# Altium schematic sheet PAGE2 - STM NUCLEO OTII TC.SchDoc("PAGE2 - STM NUCLEO OTII TC")
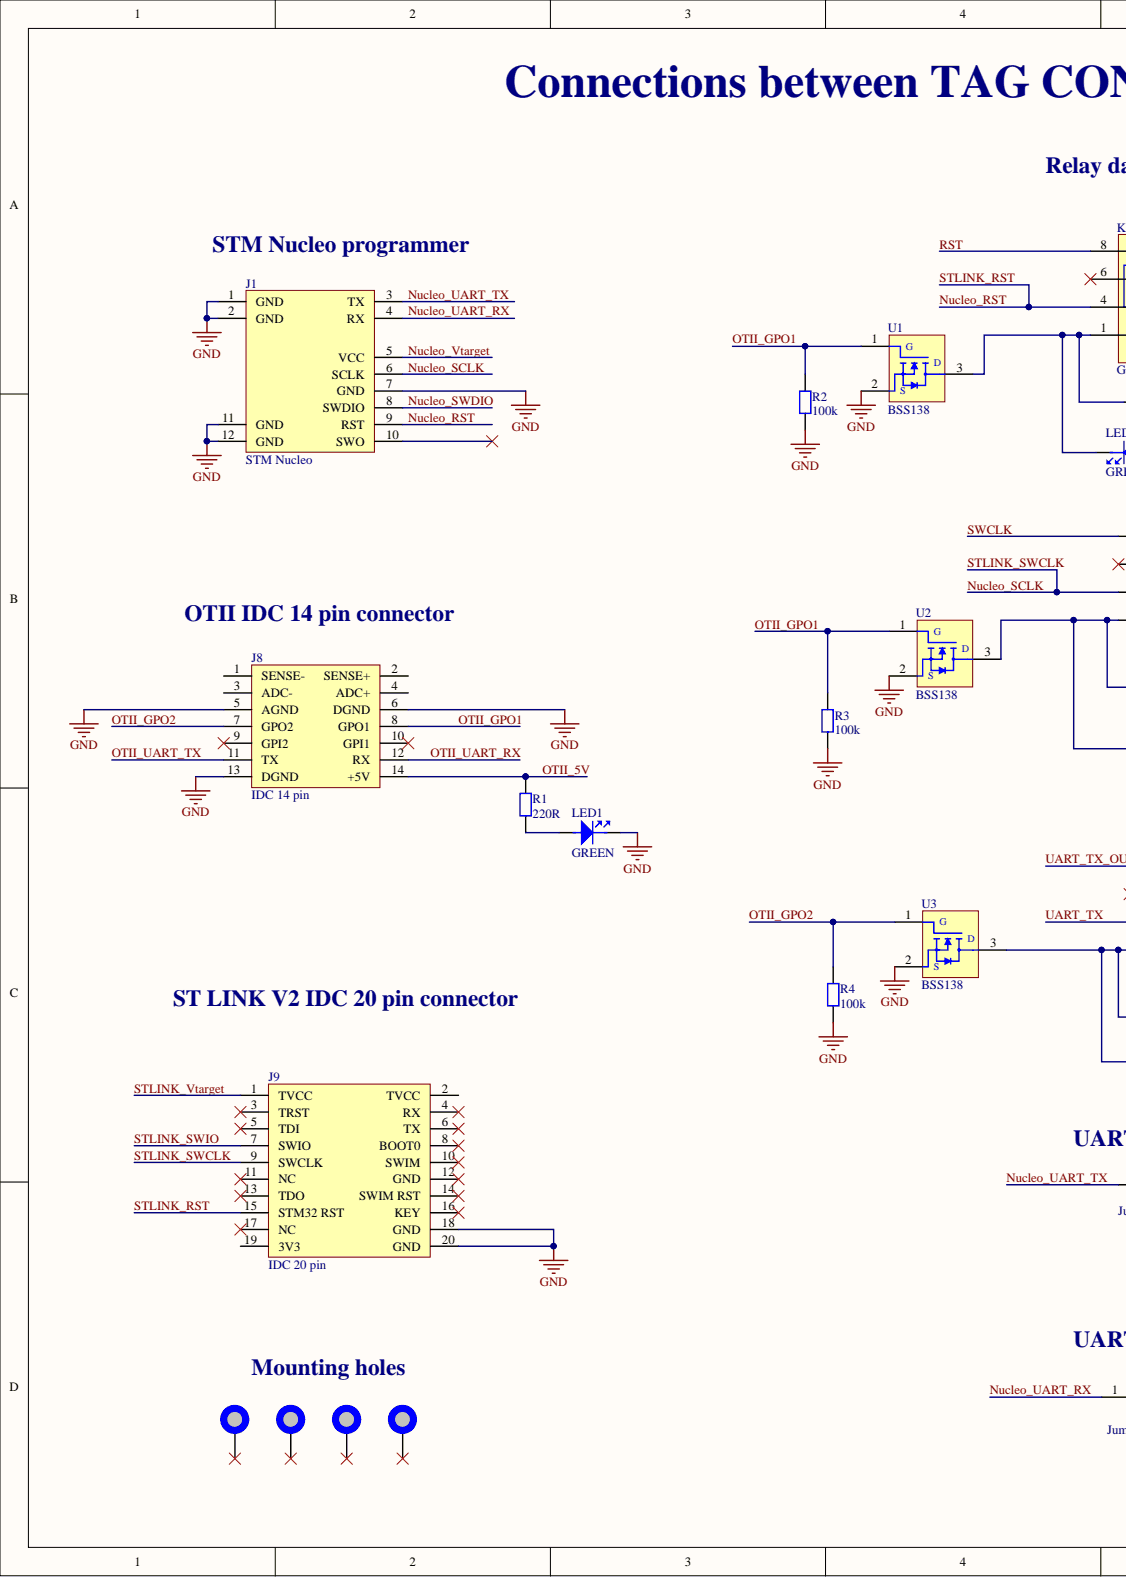
[diagram p0: PAGE2 - STM NUCLEO OTII TC.SchDoc, left]
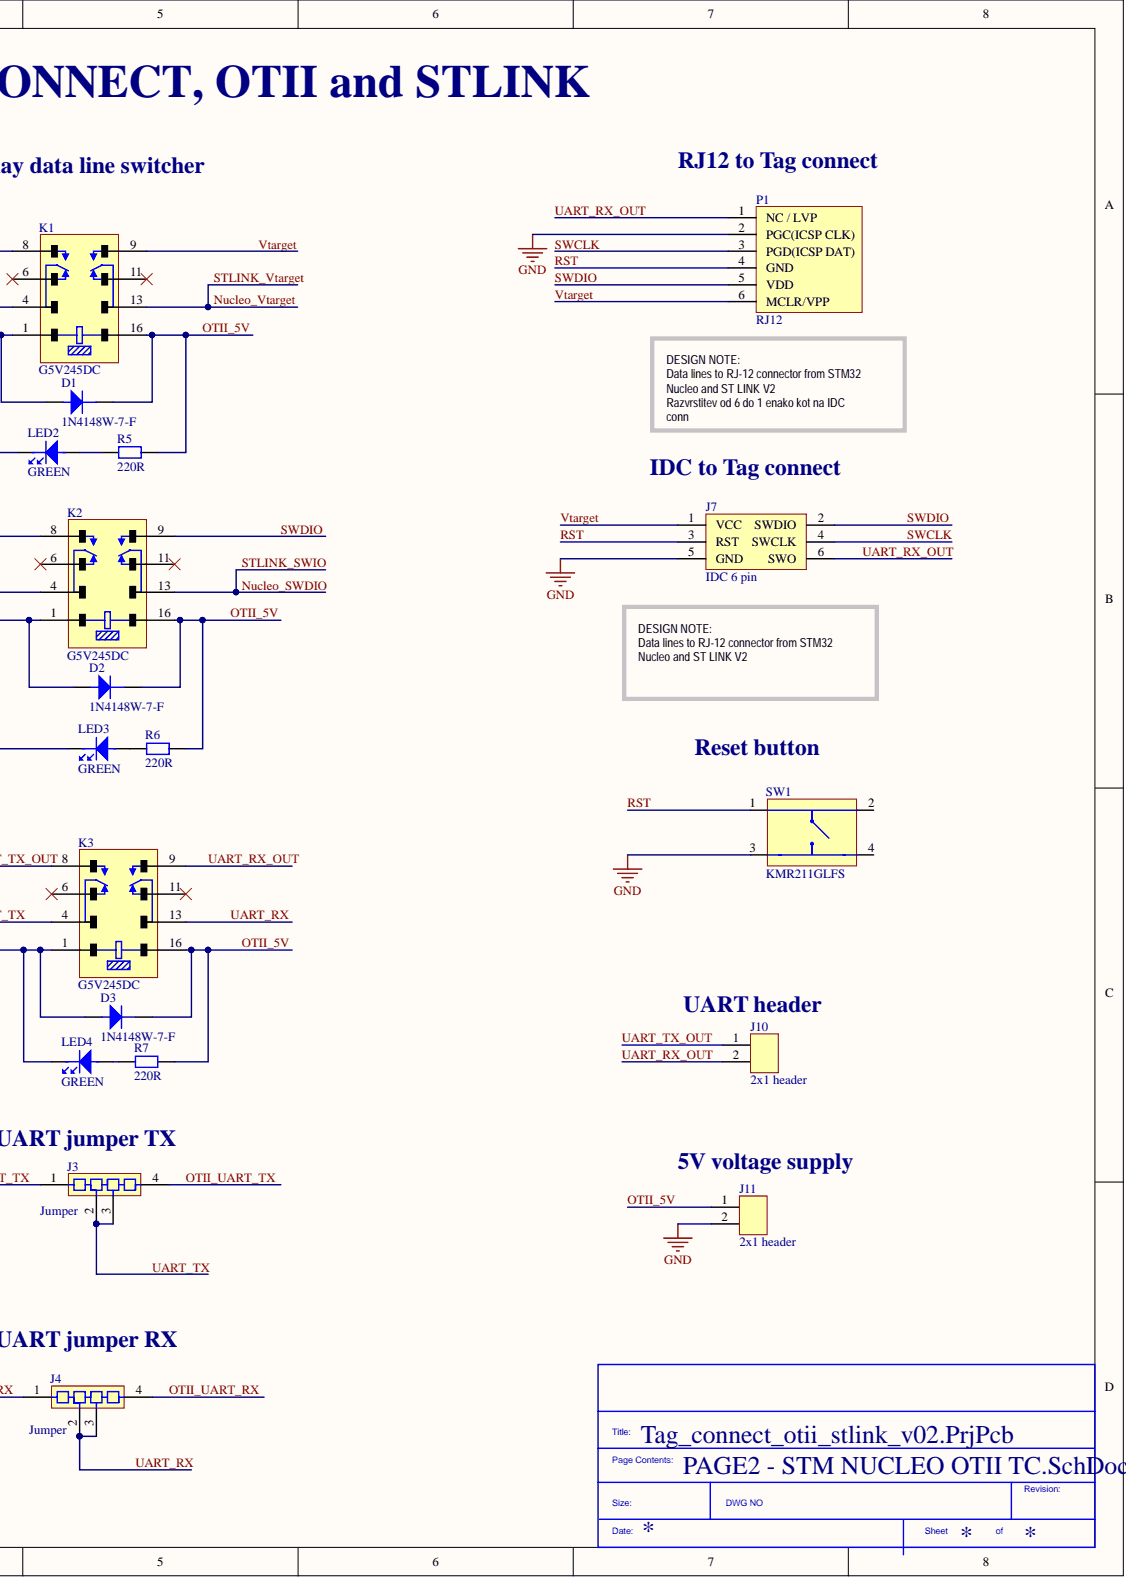
[diagram p1: PAGE2 - STM NUCLEO OTII TC.SchDoc, right]

## Parts
*  Mounting hole 3.3 mm  [MH_3.3]  (value not drawn)
*  Mounting hole 3.3 mm  [MH_3.3]  (value not drawn)
*  Mounting hole 3.3 mm  [MH_3.3]  (value not drawn)
*  Mounting hole 3.3 mm  [MH_3.3]  (value not drawn)
D1  1N4148W-7-F  [1N4148W-7-F]
D2  1N4148W-7-F  [1N4148W-7-F]
D3  1N4148W-7-F  [1N4148W-7-F]
J1  STM Nucleo  [NUCLEO F4 HEADERS]
J3  Jumper  [jumper4]
J4  Jumper  [jumper4]
J7  IDC 6 pin  [IDC 6 pin]
J8  IDC 14 pin  [IDC 14 PIN]
J9  IDC 20 pin  [IDC 20 pin]
J10  2x1 header  [2 pin]
J11  2x1 header  [2 pin]
K1  G5V245DC  [G5V245DC]
K2  G5V245DC  [G5V245DC]
K3  G5V245DC  [G5V245DC]
LED1  GREEN  [LED 0603]
LED2  GREEN  [LED 0603]
LED3  GREEN  [LED 0603]
LED4  GREEN  [LED 0603]
P1  RJ12  [RJ12]
R1  220R  [R0603]
R2  100k  [R0603]
R3  100k  [R0603]
R4  100k  [R0603]
R5  220R  [R0603]
R6  220R  [R0603]
R7  220R  [R0603]
SW1  KMR211GLFS  [Button]
U1  BSS138  [BSS138]
U2  BSS138  [BSS138]
U3  BSS138  [BSS138]

## Nets
GND: J1.1(GND) J1.2(GND) J1.7(GND) J1.11(GND) J1.12(GND) J7.5(GND) J8.5(AGND) J8.6(DGND) J8.13(DGND) J9.18(GND) J9.20(GND) J11.2 LED1.1 P1.2(PGC(ICSP_CLK)) R2.1 R3.1 R4.1 SW1.3 U1.2 U2.2 U3.2
Nucleo_RST: J1.9(RST) J9.15(STM32_RST) K1.4
Nucleo_SCLK: J1.6(SCLK) J9.9(SWCLK) K2.4
Nucleo_SWDIO: J1.8(SWDIO) J9.7(SWIO) K2.13
Nucleo_UART_RX: J1.4(RX) J4.1
Nucleo_UART_TX: J1.3(TX) J3.1
Nucleo_Vtarget: J1.5(VCC) J9.1(TVCC) K1.13
OTII_5V: D1.1 D2.1 D3.1 J8.14(+5V) J11.1 K1.16 K2.16 K3.16 R1.2 R5.1 R6.1 R7.2
OTII_GPO1: J8.8(GPO1) R2.2 R3.2 U1.1 U2.1
OTII_GPO2: J8.7(GPO2) R4.2 U3.1
OTII_UART_RX: J4.4 J8.12(RX)
OTII_UART_TX: J3.4 J8.11(TX)
RST: J7.3(RST) K1.8 P1.4(GND) SW1.1
SWCLK: J7.4(SWCLK) K2.8 P1.3(PGD(ICSP_DAT))
SWDIO: J7.2(SWDIO) K2.9 P1.5(VDD)
UART_RX: J4.2 J4.3 K3.13
UART_RX_OUT: J7.6(SWO) J10.2 K3.9 P1.1(NC_/_LVP)
UART_TX: J3.2 J3.3 K3.4
UART_TX_OUT: J10.1 K3.8
Vtarget: J7.1(VCC) K1.9 P1.6(MCLR/VPP)
NetD1_2: D1.2 K1.1 LED2.1 U1.3
NetD2_2: D2.2 K2.1 LED3.1 U2.3
NetD3_2: D3.2 K3.1 LED4.1 U3.3
NetLED1_2: LED1.2 R1.1
NetLED2_2: LED2.2 R5.2
NetLED3_2: LED3.2 R6.2
NetLED4_2: LED4.2 R7.1

Not connected: * 1; J1 10(SWO); J8 1(SENSE-) 2(SENSE+) 3(ADC-) 4(ADC+) 9(GPI2) 10(GPI1); J9 2(TVCC) 3(TRST) 4(RX) 5(TDI) 6(TX) 8(BOOT0) 10(SWIM) 11(NC) 12(GND) 13(TDO) 14(SWIM_RST) 16(KEY) 17(NC) 19(3V3); K1 6 11; K2 6 11; K3 6 11; SW1 2 4

## Library data of the parts
* * * * = MH_3_3: Description: Mounting hole 3.3 mm; Component Kind: Standard; Library Name: TC_otii_stlink.SchLib
D1-D3 = 1N4148W-7-F: DIODE GEN PURP 100V 300MA SOD123; Manufacturer: Diodes; Voltage Rating (DC): 75V; Reverse Recovery Time: 4ns; Power Rating: 400mW; Polarity: Standard; Peak Reverse Current: 1uA; Peak Non-Repetitive Surge Current: 2A; Output Current: 150mA; Forward Voltage: 1.25V; Element Configuration: Single; Capacitance: 2pF; Width: 1.7mm; Weight: 0.000353oz; Voltage: 100V; Supplier Part Number 1: 1776392; Supplier 1: Farnell; Stock 1: 994731; Recovery Time: 4ns; REACH SVHC: No SVHC; Radiation Hardening: No; Pricing 1: 5=0.155, 25=0.151, 100=0.0525, 250=0.0496, 500=0.0474, 1000=0.0247, 5000=0.0213 (EUR); Power Dissipation: 400mW; Packaging: Tape and Reel; Package Quantity: 3000; Number of Pins: 2; Mount: Surface Mount; Min Operating Temperature: -65°C; Max Surge Current: 2A; Max Reverse Voltage (DC): 100V; Max Repetitive Reverse Voltage (Vrrm): 100V; Max Operating Temperature: 150°C; Max Forward Surge Current (Ifsm): 2A; Manufacturer Part Number: 1N4148W-7-F; Length: 2.85mm; Lead Free: Lead Free; Height: 1.25mm; Forward Current: 150mA; Current Rating: 150mA; Contact Plating: Tin,Matte; ComponentLink6URL: https://www.diodes.com/assets/Datasheets/ds30086.pdf?src-supplier=Verical; ComponentLink6Description: https://www.diodes.com/assets/Datasheets/ds30086.pdf?src-supplier=Verical; ComponentLink5URL: https://www.diodes.com/assets/Datasheets/ds30086.pdf?src-supplier=Avnet+Europe; ComponentLink5Description: https://www.diodes.com/assets/Datasheets/ds30086.pdf?src-supplier=Avnet+Europe; ComponentLink4URL: http://www.mouser.com/ds/2/115/ds30086-60872.pdf?src-supplier=Mouser; ComponentLink4Description: http://www.mouser.com/ds/2/115/ds30086-60872.pdf?src-supplier=Mouser; ComponentLink3URL: https://www.diodes.com/assets/Datasheets/ds30086.pdf?src-supplier=Avnet; ComponentLink3Description: https://www.diodes.com/assets/Datasheets/ds30086.pdf?src-supplier=Avnet; ComponentLink2URL: http://www.farnell.com/datasheets/1915529.pdf?src-supplier=Element14; ComponentLink2Description: http://www.farnell.com/datasheets/1915529.pdf?src-supplier=Element14; ComponentLink1URL: https://www.diodes.com/assets/Datasheets/ds30086.pdf?src-supplier=Digi-Key; ComponentLink1Description: https://www.diodes.com/assets/Datasheets/ds30086.pdf?src-supplier=Digi-Key; Component Kind: Standard; Average Rectified Current: 300mA; Library Name: TC_otii_stlink.SchLib
J3 J4 = Jumper_1: 4 pin jumper; Component Kind: Standard; Library Name: TC_otii_stlink.SchLib
J7 = 90130-1206: Headers & Wire Housings 2.54MM CGRIDIII HDR 6P V DR SHRD AU-E; Manufacturer: Molex; Working Voltage: 350V; Number of Positions: 6; Max Voltage Rating (DC): 350.35kV; Max Voltage Rating (AC): 500V; Max Current Rating: 3.9A; Insulation Resistance: 5GR; Glow Wire Compliant: Non-compliant; Gender: Male; Current Rating: 3A; Contact Resistance: 20mR; Voltage Rating (DC): 350V; Voltage Rating (AC): 350V; Voltage Rating: 350V; Termination: Solder; Supplier Part Number 1: 9733884; Supplier 1: Farnell; Stock 1: 1896; Row Spacing: 2.54mm; REACH SVHC: Unknown; Radiation Hardening: No; Pricing 1: 1=1.07, 50=1, 100=0.934, 250=0.84, 500=0.775, 1000=0.619 (EUR); Pitch: 2.54mm; Packaging: Tray; Package Quantity: 2560; Orientation: Vertical; Number of Rows: 2; Number of Poles: 6; Number of Contacts: 6; Number of Circuits: 6; Mount: Through Hole; Min Operating Temperature: -55°C; Max Operating Temperature: 125°C; Material: Glass,Polyester; Manufacturer Part Number: 90130-1206; Length: 8mm; Lead Pitch: 2.54mm; Lead Free: Lead Free; Housing Material: Polyester; Housing Color: Black; Height: 4.7mm; Depth: 3.94mm; Contact Plating: Gold; Contact Material: Brass,Bronze,Copper; Contact Gender: Male; ComponentLink5URL: http://uk.rs-online.com/webdocs/13c4/0900766b813c4c7b.pdf?src-supplier=RSComponents; ComponentLink5Description: http://uk.rs-online.com/webdocs/13c4/0900766b813c4c7b.pdf?src-supplier=RSComponents; ComponentLink4URL: http://www.molex.com/webdocs/datasheets/pdf/en-us/0901301206_PCB_HEADERS.pdf?src-supplier=Avnet; ComponentLink4Description: http://www.molex.com/webdocs/datasheets/pdf/en-us/0901301206_PCB_HEADERS.pdf?src-supplier=Avnet; ComponentLink3URL: http://www.molex.com/webdocs/datasheets/pdf/en-us/0901301206_PCB_HEADERS.pdf?src-supplier=Avnet+Europe; ComponentLink3Description: http://www.molex.com/webdocs/datasheets/pdf/en-us/0901301206_PCB_HEADERS.pdf?src-supplier=Avnet+Europe; ComponentLink2URL: http://www.jameco.com/Jameco/Products/ProdDS/1978810.pdf?src-supplier=Jameco; ComponentLink2Description: http://www.jameco.com/Jameco/Products/ProdDS/1978810.pdf?src-supplier=Jameco; ComponentLink1URL: http://www.mouser.com/ds/2/276/0901301206_PCB_HEADERS-151694.pdf?src-supplier=Mouser; ComponentLink1Description: http://www.mouser.com/ds/2/276/0901301206_PCB_HEADERS-151694.pdf?src-supplier=Mouser; Component Kind: Standard; Color: Black; Library Name: TC_otii_stlink.SchLib
J8 = 70246-1404: Conn Shrouded Header (4 Sides) HDR 14 POS 2.54mm Solder ST Thru-Hole C-Grid® Frame; Manufacturer: Molex; Voltage Rating (DC): 250V; Number of Positions: 14; Glow Wire Compliant: Non-compliant; Gender: Male; Current Rating: 2.5A; Contact Post Length: 3.43mm; Voltage Rating: 250V; Termination: Solder; Supplier Part Number 1: 1392520; Supplier 1: Farnell; Stock 1: 3001; Row Spacing: 2.54mm; REACH SVHC: Unknown; Radiation Hardening: No; Pricing 1: 1=1.55, 50=1.48, 100=1.42, 250=1.29, 500=1.17, 1000=0.966 (EUR); Pitch: 2.54mm; Packaging: Tray; Orientation: Vertical; Number of Rows: 2; Number of Contacts: 14; Number of Circuits: 14; Mount: Through Hole; Min Operating Temperature: -55°C; Max Voltage Rating (DC): 250V; Max Operating Temperature: 120°C; Manufacturer Part Number: 70246-1404; Housing Material: Polyester; Flammability Rating: UL94 V-0; ELV: Compliant; Contact Plating: Gold,Tin; Contact Mating Length: 6.1mm; Contact Material: Bronze,Gold; Contact Gender: Male; ComponentLink5URL: http://www.molex.com/webdocs/datasheets/pdf/en-us/0702461404_PCB_HEADERS.pdf?src-supplier=Avnet+Europe; ComponentLink5Description: http://www.molex.com/webdocs/datasheets/pdf/en-us/0702461404_PCB_HEADERS.pdf?src-supplier=Avnet+Europe; ComponentLink4URL: http://www.mouser.com/ds/2/276/0702461404_PCB_HEADERS-172392.pdf?src-supplier=Mouser; ComponentLink4Description: http://www.mouser.com/ds/2/276/0702461404_PCB_HEADERS-172392.pdf?src-supplier=Mouser; ComponentLink3URL: http://uk.rs-online.com/webdocs/13c4/0900766b813c492f.pdf?src-supplier=RSComponents; ComponentLink3Description: http://uk.rs-online.com/webdocs/13c4/0900766b813c492f.pdf?src-supplier=RSComponents; ComponentLink2URL: http://www.molex.com/webdocs/datasheets/pdf/en-us/0702461404_PCB_HEADERS.pdf?src-supplier=Avnet; ComponentLink2Description: http://www.molex.com/webdocs/datasheets/pdf/en-us/0702461404_PCB_HEADERS.pdf?src-supplier=Avnet; ComponentLink1URL: http://www.farnell.com/datasheets/2051710.pdf?src-supplier=Element14; ComponentLink1Description: http://www.farnell.com/datasheets/2051710.pdf?src-supplier=Element14; Component Kind: Standard; Library Name: TC_otii_stlink.SchLib
J9 = 70246-2004: Headers & Wire Housings 2.54mm CGrid Hdr Shr d /Slt .13AuLF 20Ckt; Manufacturer: Molex; Voltage Rating (DC): 250V; Number of Positions: 20; Glow Wire Compliant: Non-compliant; Gender: Male; Current Rating: 2.5A; Voltage Rating: 250V; Termination: Solder; Supplier Part Number 1: 1392410; Supplier 1: Farnell; Stock 1: 2985; REACH SVHC: Unknown; Radiation Hardening: No; Pricing 1: 1=1.62, 50=1.52, 100=1.41, 250=1.28, 500=1.17, 1000=0.943 (EUR); Pitch: 2.54mm; Packaging: Tray; Package Quantity: 720; Orientation: Vertical; Number of Rows: 2; Number of Contacts: 20; Number of Circuits: 20; Mount: Through Hole; Min Operating Temperature: -55°C; Max Voltage Rating (DC): 250V; Max Operating Temperature: 120°C; Manufacturer Part Number: 70246-2004; Flammability Rating: UL94 V-0; ELV: Compliant; Contact Plating: Gold; Contact Material: Gold,Bronze; ComponentLink5URL: http://uk.rs-online.com/webdocs/13c4/0900766b813c4938.pdf?src-supplier=RSComponents; ComponentLink5Description: http://uk.rs-online.com/webdocs/13c4/0900766b813c4938.pdf?src-supplier=RSComponents; ComponentLink4URL: http://www.molex.com/webdocs/datasheets/pdf/en-us/0702462004_PCB_HEADERS.pdf?src-supplier=Avnet; ComponentLink4Description: http://www.molex.com/webdocs/datasheets/pdf/en-us/0702462004_PCB_HEADERS.pdf?src-supplier=Avnet; ComponentLink3URL: http://www.molex.com/webdocs/datasheets/pdf/en-us/0702462004_PCB_HEADERS.pdf?src-supplier=Avnet+Europe; ComponentLink3Description: http://www.molex.com/webdocs/datasheets/pdf/en-us/0702462004_PCB_HEADERS.pdf?src-supplier=Avnet+Europe; ComponentLink2URL: http://www.mouser.com/ds/2/276/0702462004_PCB_HEADERS-150189.pdf?src-supplier=Mouser; ComponentLink2Description: http://www.mouser.com/ds/2/276/0702462004_PCB_HEADERS-150189.pdf?src-supplier=Mouser; ComponentLink1URL: http://www.farnell.com/datasheets/2051704.pdf?src-supplier=Element14; ComponentLink1Description: http://www.farnell.com/datasheets/2051704.pdf?src-supplier=Element14; Component Kind: Standard; Library Name: TC_otii_stlink.SchLib
J10 J11 = 2 pin header: Component Kind: Standard; Library Name: TC_otii_stlink.SchLib
K1-K3 = G5V245DC: OMRON ELECTRONIC COMPONENTS         G5V-2 4.5DC            Signal Relay, G5V-2 Series, DPDT, 4.5 VDC, 2 A, Through Hole, Non Latching; Switching Current: 2A; Coil Voltage (DC): 4.5V; Coil Type: Non-Latching; Coil Resistance: 40R; Coil Power: 500mW; Termination: Through Hole; Supplier Part Number 1: 9949810; Supplier 1: Farnell; Stock 1: 337; REACH SVHC: Unknown; Pricing 1: 1=1.89, 25=1.76, 50=1.24, 250=1.14, 500=1.12 (EUR); Mount: PCB; Min Operating Temperature: -25°C; Max Operating Temperature: 65°C; Manufacturer Part Number: G5V245DC; Manufacturer: Omron; Length: 20.5mm; Height: 11.5mm; Depth: 10.1mm; Contact Material: Silver,Gold; Contact Current Rating: 2A; ComponentLink2URL: https://www.distrelec.biz/Web/Downloads/_t/ds/g5v2_eng_tds.pdf?mime=application%2Fpdf&src-supplier=Distrelec; ComponentLink2Description: https://www.distrelec.biz/Web/Downloads/_t/ds/g5v2_eng_tds.pdf?mime=application%2Fpdf&src-supplier=Distrelec; ComponentLink1URL: http://www.farnell.com/datasheets/724502.pdf?src-supplier=Element14; ComponentLink1Description: http://www.farnell.com/datasheets/724502.pdf?src-supplier=Element14; Component Kind: Standard; Library Name: TC_otii_stlink.SchLib
LED1-LED4 = KP-1608CGCK: KINGBRIGHT - KP-1608CGCK - LED, 0603, 50MCD, GREEN; Wavelength: 570nm; Viewing Angle: 120°; Number of LEDs: 1; Lens Style: Rectangular,Diffused,Colorless; Lens Color: Clear; Forward Voltage: 2.1V; Forward Current: 20mA; Width: 1.6mm; Supplier Part Number 1: 2290328; Supplier 1: Farnell; Stock 1: 18535; REACH SVHC: No SVHC; Radiation Hardening: No; Pricing 1: 5=0.157, 50=0.143, 100=0.0934, 500=0.0789, 1000=0.0621 (EUR); Packaging: Tape and Reel; Number of Pins: 2; Mount: Surface Mount; Manufacturer Part Number: KP-1608CGCK; Manufacturer: Kingbright; Luminous Intensity: 50mcd; Length: 0.8mm; Height: 1.1mm; Dominant Wavelength: 570nm; ComponentLink2URL: http://www.rapidonline.com/pdf/72-8670.pdf?src-supplier=Rapid+Electronics; ComponentLink2Description: http://www.rapidonline.com/pdf/72-8670.pdf?src-supplier=Rapid+Electronics; ComponentLink1URL: http://www.farnell.com/datasheets/2045956.pdf?src-supplier=Element14; ComponentLink1Description: http://www.farnell.com/datasheets/2045956.pdf?src-supplier=Element14; Component Kind: Standard; Color: Green; Case/Package: 1608; Library Name: TC_otii_stlink.SchLib
P1 = 95501-2661: MODULAR, JACK, THT, R/A, RJ11, 6P6C; Manufacturer: Molex; Voltage Rating (DC): 125V; Shielding: Unshielded; Pitch: 2.54mm; Number of Positions: 6; Number of Ports: 1; Insulation Resistance: 500MR; Gender: Female; Current Rating: 1.5A; Approvals: UL; Width: 11.5mm; Termination: Through Hole; Supplier Part Number 1: 1536490; Supplier 1: Farnell; Stock 1: 7441; REACH SVHC: Unknown; Pricing 1: 10=0.565, 100=0.492, 500=0.443, 1000=0.394, 2500=0.356, 5000=0.344 (EUR); Packaging: Tray; Package Quantity: 3276; Orientation: Right Angle; Number of Contacts: 6; Mount: Through Hole; Min Operating Temperature: -40°C; Max Voltage Rating (DC): 125V; Max Operating Temperature: 80°C; Manufacturer Part Number: 95501-2661; Length: 13.21mm; Lead Free: Lead Free; Insulation Material: Polyester; Housing Material: Thermoplastic; Halogen Free: Low Halogen; Flammability Rating: UL94 V-0; Depth: 18.1mm; Contact Plating: Gold; Contact Material: Copper Alloy,Bronze; ComponentLink4URL: http://www.onlinecomponents.com/productFiles/mf-MOL/360890955012661_modular_jacks_plug.pdf?src-supplier=Onlinecomponents.com; ComponentLink4Description: http://www.onlinecomponents.com/productFiles/mf-MOL/360890955012661_modular_jacks_plug.pdf?src-supplier=Onlinecomponents.com; ComponentLink3URL: http://www.mouser.com/ds/2/276/0955012661_MODULAR_JACKS_PLUG-177638.pdf?src-supplier=Mouser; ComponentLink3Description: http://www.mouser.com/ds/2/276/0955012661_MODULAR_JACKS_PLUG-177638.pdf?src-supplier=Mouser; ComponentLink2URL: http://www.farnell.com/cad/1597654.pdf?src-supplier=Element14; ComponentLink2Description: http://www.farnell.com/cad/1597654.pdf?src-supplier=Element14; ComponentLink1URL: http://uk.rs-online.com/webdocs/13c4/0900766b813c4eac.pdf?src-supplier=RSComponents; ComponentLink1Description: http://uk.rs-online.com/webdocs/13c4/0900766b813c4eac.pdf?src-supplier=RSComponents; Component Kind: Standard; Color: Black; Library Name: TC_otii_stlink.SchLib
R1 R5-R7 = CRCW0603220RFKEA: RES SMD 220 OHM 1% 1/10W 0603; Manufacturer: Vishay; Voltage Rating (DC): 75V; Temperature Coefficient: 100ppm/°C; Power Rating: 100mW; Width: 0.033inch; Weight: 7.1E-05oz; Voltage Rating: 75V; Tolerance: 1%; Termination: SMD/SMT; Supplier Part Number 1: 541-220HTR-ND; Supplier 1: Digi-Key; Stock 1: 0; Resistance: 220R; REACH SVHC: Unknown; Radiation Hardening: No; Packaging: Tape and Reel; Package Quantity: 10; Number of Terminations: 2; Min Operating Temperature: -55°C; Military Standard: Not; Max Power Dissipation: 100mW; Max Operating Temperature: 155°C; Manufacturer Part Number: CRCW0603220RFKEA; Length: 1.55mm; Lead Free: Lead Free; Height: 0.45mm; Features: Automotive AEC-Q200; Depth: 0.85mm; Contact Plating: Tin; Composition: Thick Film; ComponentLink11URL: http://www.farnell.com/datasheets/2111203.pdf?src-supplier=Element14; ComponentLink11Description: http://www.farnell.com/datasheets/2111203.pdf?src-supplier=Element14; ComponentLink10URL: https://www.distrelec.biz/Web/Downloads/a_/en/flD-CRCW-e3_data_en.pdf?mime=application%2Fpdf&src-supplier=Distrelec; ComponentLink10Description: https://www.distrelec.biz/Web/Downloads/a_/en/flD-CRCW-e3_data_en.pdf?mime=application%2Fpdf&src-supplier=Distrelec; ComponentLink9URL: http://www.vishay.com/docs/20035/dcrcwe3.pdf?src-supplier=Avnet+Europe; ComponentLink9Description: http://www.vishay.com/docs/20035/dcrcwe3.pdf?src-supplier=Avnet+Europe; ComponentLink8URL: https://www.verical.com/server-webapp/html/getDatasheet?partID=45816&src-supplier=Verical; ComponentLink8Description: https://www.verical.com/server-webapp/html/getDatasheet?partID=45816&src-supplier=Verical; ComponentLink7URL: https://www.alliedelec.com/m/d/0c934d6939ccefa68dbe0b96d821c8cf.pdf?src-supplier=Allied+Electronics; ComponentLink7Description: https://www.alliedelec.com/m/d/0c934d6939ccefa68dbe0b96d821c8cf.pdf?src-supplier=Allied+Electronics; ComponentLink6URL: http://uk.rs-online.com/webdocs/0d98/0900766b80d98afc.pdf?src-supplier=RSComponents; ComponentLink6Description: http://uk.rs-online.com/webdocs/0d98/0900766b80d98afc.pdf?src-supplier=RSComponents; ComponentLink5URL: http://www.vishay.com/docs/20035/dcrcwe3.pdf?src-supplier=Future+Electronics; ComponentLink5Description: http://www.vishay.com/docs/20035/dcrcwe3.pdf?src-supplier=Future+Electronics; ComponentLink4URL: http://www.vishay.com/docs/20035/dcrcwe3.pdf?src-supplier=Avnet; ComponentLink4Description: http://www.vishay.com/docs/20035/dcrcwe3.pdf?src-supplier=Avnet; ComponentLink3URL: http://www.farnell.com/datasheets/2045673.pdf?src-supplier=Element14; ComponentLink3Description: http://www.farnell.com/datasheets/2045673.pdf?src-supplier=Element14; ComponentLink2URL: http://www.mouser.com/ds/2/427/dcrcwe3-109170.pdf?src-supplier=Mouser; ComponentLink2Description: http://www.mouser.com/ds/2/427/dcrcwe3-109170.pdf?src-supplier=Mouser; ComponentLink1URL: http://www.vishay.com/docs/20035/dcrcwe3.pdf?src-supplier=Digi-Key; ComponentLink1Description: http://www.vishay.com/docs/20035/dcrcwe3.pdf?src-supplier=Digi-Key; Component Kind: Standard; Case Code (Metric): 1608; Case Code (Imperial): 0603; Case/Package: 0603; Library Name: TC_otii_stlink.SchLib
R2-R4 = CPF-A-0603B100KE1: TE CONNECTIVITY         CPF-A-0603B100KE1             SMD Chip Resistor, Thin Film, 100 kohm, 50 V, 0603 [1608 Metric], 62.5 mW,  0.1%, CPF-A Series; Voltage Rating (DC): 50V; Temperature Coefficient: 25ppm/°C; Resistance: 100kR; Power Rating: 63mW; Number of Resistors: 1; Voltage Rating: 50V; Tolerance: 0.1%; Termination: Solder; Supplier Part Number 1: 2483881; Supplier 1: Farnell; Stock 1: 5473; REACH SVHC: No SVHC; Pricing 1: 1=0.378, 50=0.279, 100=0.21, 250=0.199, 500=0.173, 1000=0.137, 5000=0.111 (EUR); Packaging: Tape and Reel; Number of Terminations: 2; Mount: Surface Mount; Min Operating Temperature: -55°C; Max Power Dissipation: 63mW; Max Operating Temperature: 155°C; Manufacturer Part Number: CPF-A-0603B100KE1; Manufacturer: TE Connectivity; Features: Moisture Resistant,Automotive AEC-Q200,Anti-Sulfur; ELV: Compliant; Composition: Thin Film; Component Kind: Standard; Case Code (Metric): 1608; Case Code (Imperial): 0603; Case/Package: 0603; Library Name: TC_otii_stlink.SchLib
SW1 = KMR211GLFS: SWITCH TACTILE SPST-NO 0.05A 32V; Manufacturer: ITT C&K; Throw Configuration: SPST; Switch Travel: 0.2mm; Switch Function: Off-Mom; Power Rating: 1W; Operating Force: 1.2N; Mechanical Life: 200000Cycles; Max Current Rating: 50mA; Illumination Type: Non-Illuminated,Illuminated; Actuator Type: Standard; Actuator Length: 0.5mm; Width: 2.8mm; Voltage Rating (DC): 32V; Termination: Gull Wing; Supplier Part Number 1: 1437635RL; Supplier 1: Farnell; Stock 1: 0; REACH SVHC: No SVHC; Radiation Hardening: No; Packaging: Tape and Reel; Package Quantity: 1; Orientation: Straight; Mount: Surface Mount; Min Operating Temperature: -40°C; Max Voltage Rating (DC): 32V; Max Operating Temperature: 85°C; Manufacturer Part Number: KMR211GLFS; Length: 2.8mm; Lead Free: Lead Free; Depth: 4.6mm; Current Rating: 50mA; Contact Plating: Silver; Contact Material: Silver; Contact Current Rating: 50mA; ComponentLink7URL: http://www.ckswitches.com/media/1479/kmr2.pdf?src-supplier=Onlinecomponents.com; ComponentLink7Description: http://www.ckswitches.com/media/1479/kmr2.pdf?src-supplier=Onlinecomponents.com; ComponentLink6URL: https://www.ckswitches.com/media/1479/kmr2.pdf?src-supplier=Digi-Key; ComponentLink6Description: https://www.ckswitches.com/media/1479/kmr2.pdf?src-supplier=Digi-Key; ComponentLink5URL: http://www.ck-components.com/14414/kmr2_9aug12.pdf/?src-supplier=Avnet+Europe; ComponentLink5Description: http://www.ck-components.com/14414/kmr2_9aug12.pdf/?src-supplier=Avnet+Europe; ComponentLink4URL: http://www.ck-components.com/14414/kmr2_9aug12.pdf/?src-supplier=Avnet; ComponentLink4Description: http://www.ck-components.com/14414/kmr2_9aug12.pdf/?src-supplier=Avnet; ComponentLink3URL: http://www.farnell.com/datasheets/2044619.pdf?src-supplier=Element14; ComponentLink3Description: http://www.farnell.com/datasheets/2044619.pdf?src-supplier=Element14; ComponentLink2URL: http://www1.futureelectronics.com/doc/C%20-%20K%20COMPONENTS/KMR211GLFS.pdf?src-supplier=Future+Electronics; ComponentLink2Description: http://www1.futureelectronics.com/doc/C%20-%20K%20COMPONENTS/KMR211GLFS.pdf?src-supplier=Future+Electronics; ComponentLink1URL: http://uk.rs-online.com/webdocs/129c/0900766b8129c9f0.pdf?src-supplier=RSComponents; ComponentLink1Description: http://uk.rs-online.com/webdocs/129c/0900766b8129c9f0.pdf?src-supplier=RSComponents; Component Kind: Standard; Library Name: TC_otii_stlink.SchLib
U1-U3 = BSS138: MOSFET N-CH 50V 220MA SOT-23; Manufacturer: ON Semiconductor / Fairchild; Turn-On Delay Time: 2.5ns; Turn-Off Delay Time: 20ns; Threshold Voltage: 1.3V; Rise Time: 9ns; Rds On Max: 3.5R; Power Dissipation: 360mW; On-State Resistance: 6R; Number of Elements: 1; Input Capacitance: 27pF; Gate to Source Voltage (Vgs): 20V; Element Configuration: Single; Width: 1.3mm; Weight: 0.03g; Voltage Rating (DC): 50V; Termination: SMD/SMT; Supplier Part Number 1: 9845330; Supplier 1: Farnell; Stock 1: 727806; REACH SVHC: No SVHC; Radiation Hardening: No; Pricing 1: 5=0.286, 50=0.261, 100=0.143, 500=0.0495, 1500=0.0464 (EUR); Packaging: Tape and Reel; Package Quantity: 1; Number of Pins: 3; Nominal Vgs: 1.3V; Mount: Surface Mount; Min Operating Temperature: -55°C; Max Power Dissipation: 360mW; Max Operating Temperature: 150°C; Manufacturer Part Number: BSS138; Length: 2.92mm; Lead Free: Lead Free; Height: 0.93mm; Fall Time: 9ns; Dual Supply Voltage: 50V; Drain to Source Voltage (Vdss): 50V; Drain to Source Resistance: 3.5R; Drain to Source Breakdown Voltage: 50V; Current Rating: 220mA; Continuous Drain Current (ID): 220mA; Contact Plating: Tin,Matte; ComponentLink8URL: http://www.fairchildsemi.com/ds/BS/BSS138.pdf?src-supplier=Avnet+Europe; ComponentLink8Description: http://www.fairchildsemi.com/ds/BS/BSS138.pdf?src-supplier=Avnet+Europe; ComponentLink7URL: http://www.jameco.com/Jameco/Products/ProdDS/784896.pdf?src-supplier=Jameco; ComponentLink7Description: http://www.jameco.com/Jameco/Products/ProdDS/784896.pdf?src-supplier=Jameco; ComponentLink6URL: http://www.fairchildsemi.com/ds/BS/BSS138.pdf?src-supplier=Future+Electronics; ComponentLink6Description: http://www.fairchildsemi.com/ds/BS/BSS138.pdf?src-supplier=Future+Electronics; ComponentLink5URL: http://www.farnell.com/datasheets/193736.pdf?src-supplier=Element14; ComponentLink5Description: http://www.farnell.com/datasheets/193736.pdf?src-supplier=Element14; ComponentLink4URL: https://www.fairchildsemi.com/datasheets/BS/BSS138.pdf?src-supplier=Avnet; ComponentLink4Description: https://www.fairchildsemi.com/datasheets/BS/BSS138.pdf?src-supplier=Avnet; ComponentLink3URL: http://www.farnell.com/datasheets/2304519.pdf?src-supplier=Newark; ComponentLink3Description: http://www.farnell.com/datasheets/2304519.pdf?src-supplier=Newark; ComponentLink2URL: http://www.farnell.com/datasheets/2163920.pdf?src-supplier=Element14; ComponentLink2Description: http://www.farnell.com/datasheets/2163920.pdf?src-supplier=Element14; ComponentLink1URL: https://www.fairchildsemi.com/package-drawings/MA/MA03B.pdf?src-supplier=Digi-Key; ComponentLink1Description: https://www.fairchildsemi.com/package-drawings/MA/MA03B.pdf?src-supplier=Digi-Key; Component Kind: Standard; Case/Package: SOT-23; Library Name: TC_otii_stlink.SchLib
J1 = STM Nucleo: Component Kind: Standard; Library Name: TC_otii_stlink.SchLib
